# Altium schematic sheet Macro_Keyboard_SCH_rev1.SchDoc
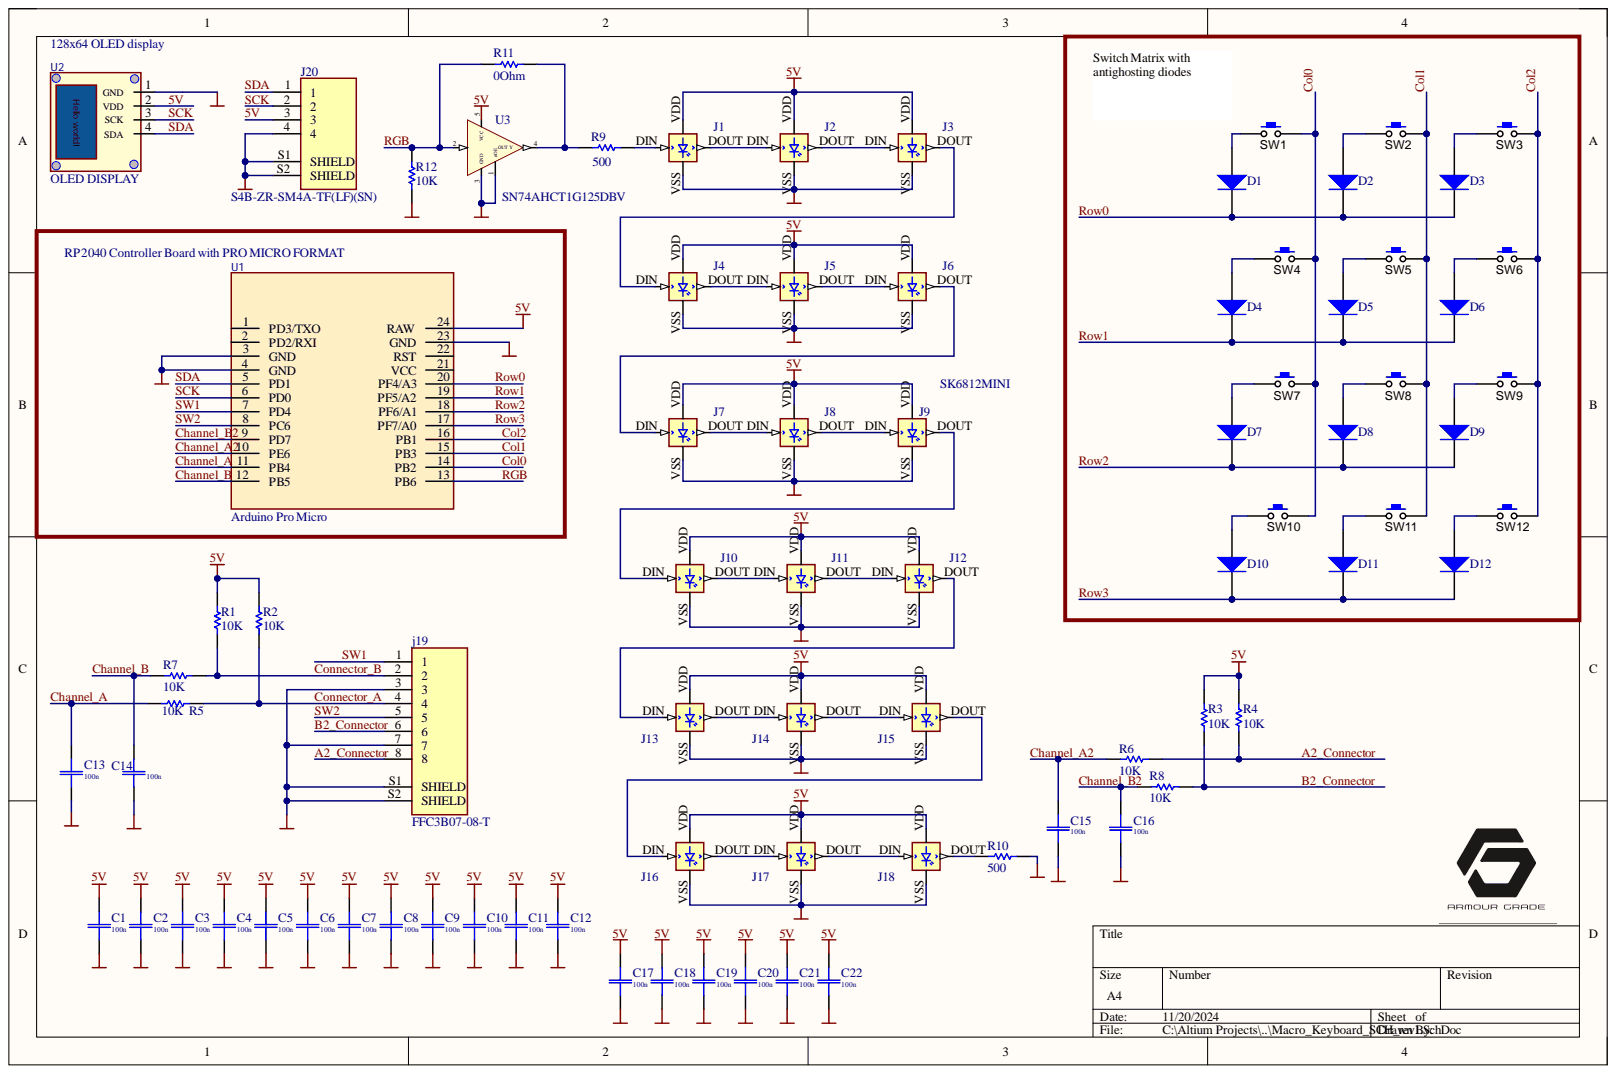
[diagram p0: Macro_Keyboard_SCH_rev1.SchDoc]

## Parts
C1  100n  [C0805(2013)]
C2  100n  [C0805(2013)]
C3  100n  [C0805(2013)]
C4  100n  [C0805(2013)]
C5  100n  [C0805(2013)]
C6  100n  [C0805(2013)]
C7  100n  [C0805(2013)]
C8  100n  [C0805(2013)]
C9  100n  [C0805(2013)]
C10  100n  [C0805(2013)]
C11  100n  [C0805(2013)]
C12  100n  [C0805(2013)]
C13  100n  [C0805(2013)]
C14  100n  [C0805(2013)]
C15  100n  [C0805(2013)]
C16  100n  [C0805(2013)]
C17  100n  [C0805(2013)]
C18  100n  [C0805(2013)]
C19  100n  [C0805(2013)]
C20  100n  [C0805(2013)]
C21  100n  [C0805(2013)]
C22  100n  [C0805(2013)]
D1  Diode  [D6-0805_N]  (value not drawn)
D2  Diode  [D6-0805_N]  (value not drawn)
D3  Diode  [D6-0805_N]  (value not drawn)
D4  Diode  [D6-0805_N]  (value not drawn)
D5  Diode  [D6-0805_N]  (value not drawn)
D6  Diode  [D6-0805_N]  (value not drawn)
D7  Diode  [D6-0805_N]  (value not drawn)
D8  Diode  [D6-0805_N]  (value not drawn)
D9  Diode  [D6-0805_N]  (value not drawn)
D10  Diode  [D6-0805_N]  (value not drawn)
D11  Diode  [D6-0805_N]  (value not drawn)
D12  Diode  [D6-0805_N]  (value not drawn)
J1  SK6812MINI  [SK6812MINIE]
J2  SK6812MINI  [SK6812MINIE]
J3  SK6812MINI  [SK6812MINIE]
J4  SK6812MINI  [SK6812MINIE]
J5  SK6812MINI  [SK6812MINIE]
J6  SK6812MINI  [SK6812MINIE]
J7  SK6812MINI  [SK6812MINIE]
J8  SK6812MINI  [SK6812MINIE]
J9  SK6812MINI  [SK6812MINIE]
J10  SK6812MINI  [SK6812MINIE]
J11  SK6812MINI  [SK6812MINIE]
J12  SK6812MINI  [SK6812MINIE]
J13  SK6812_SIDE_MOUNT  [SK6812_SIDE_MOUNT]  (value not drawn)
J14  SK6812_SIDE_MOUNT  [SK6812_SIDE_MOUNT]  (value not drawn)
J15  SK6812_SIDE_MOUNT  [SK6812_SIDE_MOUNT]  (value not drawn)
J16  SK6812_SIDE_MOUNT  [SK6812_SIDE_MOUNT]  (value not drawn)
J17  SK6812_SIDE_MOUNT  [SK6812_SIDE_MOUNT]  (value not drawn)
J18  SK6812_SIDE_MOUNT  [SK6812_SIDE_MOUNT]  (value not drawn)
j19  FFC3B07-08-T  [GCT_FFC3B07-08-T]
J20  S4B-ZR-SM4A-TF(LF)(SN)  [JST_S4B-ZR-SM4A-TF(LF)(SN)]
R1  value 10K  [R0805]  (hidden comment: Res1)
R2  value 10K  [R0805]  (hidden comment: Res1)
R3  value 10K  [R0805]  (hidden comment: Res1)
R4  value 10K  [R0805]  (hidden comment: Res1)
R5  value 10K  [R0805]  (hidden comment: Res1)
R6  value 10K  [R0805]  (hidden comment: Res1)
R7  value 10K  [R0805]  (hidden comment: Res1)
R8  value 10K  [R0805]  (hidden comment: Res1)
R9  value 500  [R0805]  (hidden comment: Res1)
R10  value 500  [R0805]  (hidden comment: Res1)
R11  value 0Ohm  [R0805]  (hidden comment: Res1)
R12  value 10K  [R0805]  (hidden comment: Res1)
SW1  SW_PB_SPST_HDR  [Kailh Choc]  (value not drawn)
SW2  SW_PB_SPST_HDR  [Kailh Choc]  (value not drawn)
SW3  SW_PB_SPST_HDR  [Kailh Choc]  (value not drawn)
SW4  SW_PB_SPST_HDR  [Kailh Choc]  (value not drawn)
SW5  SW_PB_SPST_HDR  [Kailh Choc]  (value not drawn)
SW6  SW_PB_SPST_HDR  [Kailh Choc]  (value not drawn)
SW7  SW_PB_SPST_HDR  [Kailh Choc]  (value not drawn)
SW8  SW_PB_SPST_HDR  [Kailh Choc]  (value not drawn)
SW9  SW_PB_SPST_HDR  [Kailh Choc]  (value not drawn)
SW10  SW_PB_SPST_HDR  [Kailh Choc]  (value not drawn)
SW11  SW_PB_SPST_HDR  [Kailh Choc]  (value not drawn)
SW12  SW_PB_SPST_HDR  [Kailh Choc]  (value not drawn)
U1  Arduino Pro Micro  [Pro Micro Sparkfun V2 USB C]
U2  OLED DISPLAY  [oled Arduino - SMD]
U3  SN74AHCT1G125DBV  [SN74AHCT1G125]

## Nets
GND: C1.2 C2.2 C3.2 C4.2 C5.2 C6.2 C7.2 C8.2 C9.2 C10.2 C11.2 C12.2 C13.2 C14.2 C15.2 C16.2 C17.2 C18.2 C19.2 C20.2 C21.2 C22.2 J1.VSS J2.VSS J3.VSS J4.VSS J5.VSS J6.VSS J7.VSS J8.VSS J9.VSS J10.VSS J11.VSS J12.VSS J13.VSS J14.VSS J15.VSS J16.VSS J17.VSS J18.VSS j19.3 j19.7 j19.S1(SHIELD) j19.S2(SHIELD) J20.4 J20.S1(SHIELD) J20.S2(SHIELD) R10.2 R12.1 U1.3(GND) U1.4(GND) U1.23(GND) U2.1(GND) U3.1(nOE) U3.3(GND)
5V: C1.1 C2.1 C3.1 C4.1 C5.1 C6.1 C7.1 C8.1 C9.1 C10.1 C11.1 C12.1 C17.1 C18.1 C19.1 C20.1 C21.1 C22.1 J1.VDD J2.VDD J3.VDD J4.VDD J5.VDD J6.VDD J7.VDD J8.VDD J9.VDD J10.VDD J11.VDD J12.VDD J13.VDD J14.VDD J15.VDD J16.VDD J17.VDD J18.VDD J20.3 R1.2 R2.1 R3.2 R4.1 U1.24(RAW) U2.2(VDD) U3.5(VCC)
A2_Connector: j19.8 R4.2 R6.2
B2_Connector: j19.6 R3.1 R8.2
Channel_A: C13.1 R5.1 U1.11(PB4)
Channel_A2: C15.1 R6.1 U1.10(PE6)
Channel_B: C14.1 R7.1 U1.12(PB5)
Channel_B2: C16.1 R8.1 U1.9(PD7)
Col0: SW1.2 SW4.2 SW7.2 SW10.2 U1.14(PB2)
Col1: SW2.2 SW5.2 SW8.2 SW11.2 U1.15(PB3)
Col2: SW3.2 SW6.2 SW9.2 SW12.2 U1.16(PB1)
Connector_A: j19.4 R2.2 R5.2
Connector_B: j19.2 R1.1 R7.2
RGB: R11.2 R12.2 U1.13(PB6) U3.2
Row0: D1.2 D2.2 D3.2 U1.20(PF4/A3)
Row1: D4.2 D5.2 D6.2 U1.19(PF5/A2)
Row2: D7.2 D8.2 D9.2 U1.18(PF6/A1)
Row3: D10.2 D11.2 D12.2 U1.17(PF7/A0)
SCK: J20.2 U1.6(PD0) U2.3(SCK)
SDA: J20.1 U1.5(PD1) U2.4(SDA)
SW1: j19.1 U1.7(PD4)
SW2: j19.5 U1.8(PC6)
NetD1_1: D1.1 SW1.1
NetD2_1: D2.1 SW2.1
NetD3_1: D3.1 SW3.1
NetD4_1: D4.1 SW4.1
NetD5_1: D5.1 SW5.1
NetD6_1: D6.1 SW6.1
NetD7_1: D7.1 SW7.1
NetD8_1: D8.1 SW8.1
NetD9_1: D9.1 SW9.1
NetD10_1: D10.1 SW10.1
NetD11_1: D11.1 SW11.1
NetD12_1: D12.1 SW12.1
NetJ1_DIN: J1.DIN R9.2
NetJ1_DOUT: J1.DOUT J2.DIN
NetJ2_DOUT: J2.DOUT J3.DIN
NetJ3_DOUT: J3.DOUT J4.DIN
NetJ4_DOUT: J4.DOUT J5.DIN
NetJ5_DOUT: J5.DOUT J6.DIN
NetJ6_DOUT: J6.DOUT J7.DIN
NetJ7_DOUT: J7.DOUT J8.DIN
NetJ8_DOUT: J8.DOUT J9.DIN
NetJ9_DOUT: J9.DOUT J10.DIN
NetJ10_DOUT: J10.DOUT J11.DIN
NetJ11_DOUT: J11.DOUT J12.DIN
NetJ12_DOUT: J12.DOUT J13.DIN
NetJ13_DOUT: J13.DOUT J14.DIN
NetJ14_DOUT: J14.DOUT J15.DIN
NetJ15_DOUT: J15.DOUT J16.DIN
NetJ16_DOUT: J16.DOUT J17.DIN
NetJ17_DOUT: J17.DOUT J18.DIN
NetJ18_DOUT: J18.DOUT R10.1
NetR9_1: R9.1 R11.1 U3.4(OUT_Y)

Not connected: U1 1(PD3/TXO) 2(PD2/RXI) 21(VCC) 22(RST)

## Library data of the parts
C1-C12 C17-C22 = C-0805   50V 100n: SMD-0805 50V Y5V +80/-20%; Component Kind: Standard; Library Name: SMD-RCL.IntLib; Price: 0.05; Signal Integrity: Cap; Simulation: Capacitor; Value: 100n
C13-C16 = C-0805   50V X7R: SMD-0805 50V X7R 10%; Component Kind: Standard; Library Name: SMD-RCL.IntLib; Price: 0.014; Signal Integrity: Cap; Simulation: Capacitor; Value: 100n
D1-D12 = Diode: Default Diode; Code_JEDEC: DO-214-AB; Component Kind: Standard; LatestRevisionDate: 17-Jul-2002; LatestRevisionNote: Re-released for DXP Platform.; Library Name: final_keyboard_lib.SCHLIB; PackageDescription: DO-214-AB/SMC; 2 C-Bend Leads; Body 7.9 x 5.9 mm, inc. leads (LxW); PackageReference: SMC; PackageVersion: Sep-1996; Published: 8-Jun-2000; Signal Integrity: Diode; Simulation: DIODE; Publisher: Altium Limited
J1-J12 = SK6812MINI: INTEGRATED LIGHT SOURCE INTELLIGENT CONTROL OF CHIP-ON-TOP SMD TYPE LED; MF: DONGGUANG OPSCO OPTOELECTRONICS CO., LTD; Availability: Not in stock; Check_prices: https://www.snapeda.com/parts/SK6812MINI/DONGGUANG+OPSCO+OPTOELECTRONICS+CO.%252C+LTD/view-part/?ref=eda; Component Kind: Standard; Library Name: SK6812MINI.SchLib; MP: SK6812MINI; Package: Package; Price: None; SnapEDA_Link: https://www.snapeda.com/parts/SK6812MINI/DONGGUANG+OPSCO+OPTOELECTRONICS+CO.%252C+LTD/view-part/?ref=snap
J13-J18 = SK6812_SIDE_MOUNT: INTEGRATED LIGHT SOURCE INTELLIGENT CONTROL OF CHIP-ON-TOP SMD TYPE LED; MF: DONGGUANG OPSCO OPTOELECTRONICS CO., LTD; MP: SK6812MINI; Availability: Not in stock; Check_prices: https://www.snapeda.com/parts/SK6812MINI/DONGGUANG+OPSCO+OPTOELECTRONICS+CO.%252C+LTD/view-part/?ref=eda; Component Kind: Standard; Package: Package; Price: None; SnapEDA_Link: https://www.snapeda.com/parts/SK6812MINI/DONGGUANG+OPSCO+OPTOELECTRONICS+CO.%252C+LTD/view-part/?ref=snap; Library Name: ESP32 Macro Board.SCHLIB
j19 = FFC3B07-08-T: 8 Position FFC, FPC Connector Contacts, Bottom 0.039 _1.00mm_ Surface Mount, Right Angle  S4B-ZR-SM4A-TF(LF)(SN); MF: Global Connector Technology; Availability: Unavailable; Component Kind: Standard; Library Name: SnapEDAsch.SchLib; MP: FFC3B07-08-T; Package: Package Analog Devices; Price: None; SnapEDA_Link: https://www.snapeda.com/parts/FFC3B07-08-T/Global%20Connector%20Technology/view-part/4223036/?ref=snap
J20 = S4B-ZR-SM4A-TF(LF)(SN): Connector Header Surface Mount, Right Angle 4 position 0.059 (1.50mm); MF: JST Sales; Arrow_Electronics-Purchase-URL: https://snapeda.com/shop?store=Arrow+Electronics&id=449060; Availability: Unavailable; Component Kind: Standard; Library Name: S4B-ZR-SM4A-TF(LF)(SN).SchLib; MP: S4B-ZR-SM4A-TF(LF)(SN); Package: None; Price: None; SnapEDA_Link: https://www.snapeda.com/parts/S4B-ZR-SM4A-TF(LF)(SN)/JST%20Sales%20America%20Inc./view-part/449060/?ref=snap
R1-R12 = Res1: Resistor; Component Kind: Standard; LatestRevisionDate: 17-Jul-2002; LatestRevisionNote: Re-released for DXP Platform.; Library Name: final_keyboard_lib.SCHLIB; PackageDescription: Axial Device, Thru-Hole; 2 Leads; 0.3 in Pin Spacing; PackageReference: AXIAL-0.3; Published: 8-Jun-2000; Signal Integrity: Res; Simulation: RESISTOR; Publisher: Altium Limited
SW1-SW12 = SWPBT_SPST_HDR: MANF: C&K Components; MANF_P#: AP4E200SWBE; Component Kind: Standard; VALUE: SW_PB_SPST_HDR; Library Name: ESP32 Macro Board.SCHLIB
U3 = SN74AHCT1G125DCKR: Component Kind: Standard; Library Name: ESP32 Macro Board.SCHLIB
U1 = Arduino Pro Micro: Component Kind: Standard; Library Name: ESP32 Macro Board.SCHLIB
U2 = OLED DISPLAY: Component Kind: Standard; Library Name: ESP32 Macro Board.SCHLIB
